# Altium schematic sheet DDR4.SchDoc
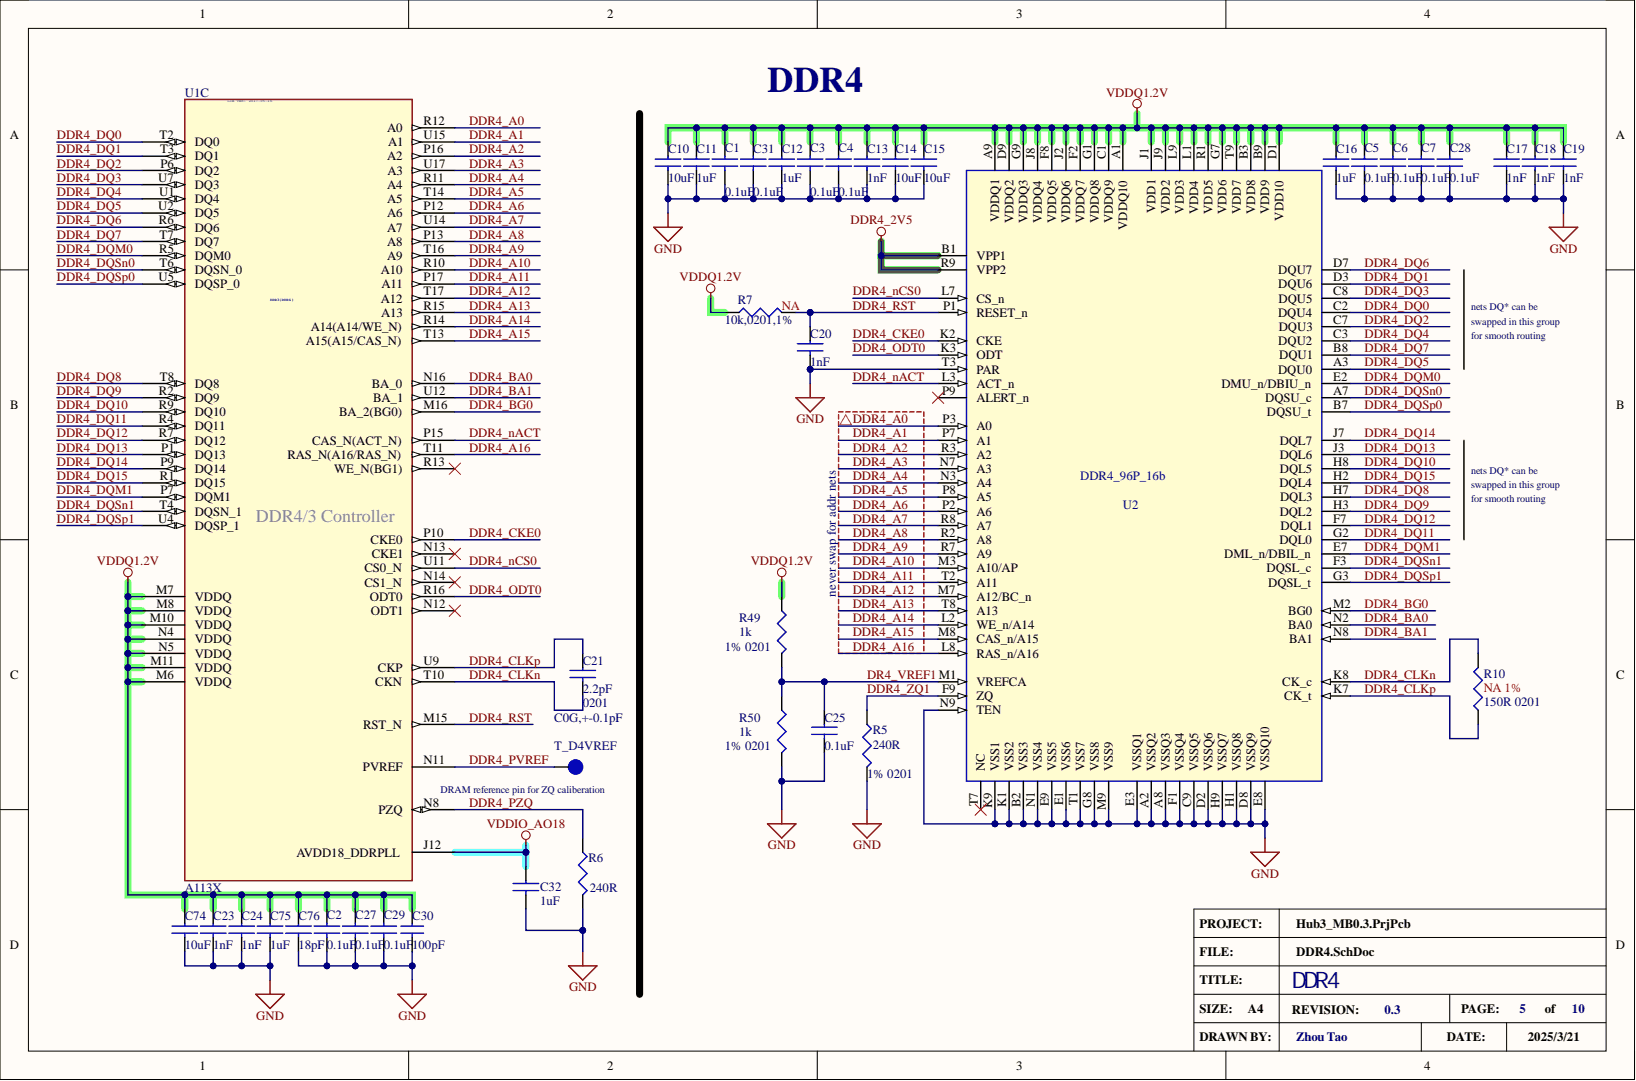
[diagram p0: DDR4.SchDoc]

## Parts
C1  0.1uF  [C0201]
C2  0.1uF  [C0201]
C3  0.1uF  [C0201]
C4  0.1uF  [C0201]
C5  0.1uF  [C0201]
C6  0.1uF  [C0201]
C7  0.1uF  [C0201]
C10  10uF  [C0603]
C11  1uF  [C0201]
C12  1uF  [C0201]
C13  value 1nF  [C0201]  (hidden comment: Cap_1nF_0201_50V)
C14  10uF  [C0603]
C15  10uF  [C0603]
C16  1uF  [C0201]
C17  value 1nF  [C0201]  (hidden comment: Cap_1nF_0201_50V)
C18  value 1nF  [C0201]  (hidden comment: Cap_1nF_0201_50V)
C19  value 1nF  [C0201]  (hidden comment: Cap_1nF_0201_50V)
C20  1nF  [C0201]
C21  0201  value 2.2pF  [C0201]
C23  value 1nF  [C0201]  (hidden comment: Cap_1nF_0201_50V)
C24  value 1nF  [C0201]  (hidden comment: Cap_1nF_0201_50V)
C25  0.1uF  [C0201]
C27  0.1uF  [C0201]
C28  0.1uF  [C0201]
C29  0.1uF  [C0201]
C30  value 100pF  [C0402]  (hidden comment: Cap_100pF_0402_50V)
C31  0.1uF  [C0201]
C32  1uF  [C0201]
C74  10uF  [C0603]
C75  1uF  [C0201]
C76  18pF  [C0402]
R5  1% 0201  value 240R  [R0201]
R6  240R  [R0201]
R7  NA  Des: 10k,0201,1%  [R0201]  (no BOM)
R10  NA 1%  value 150R 0201  [R0201]  (no BOM)
R49  1% 0201  value 1k  [R0201]
R50  1% 0201  value 1k  [R0201]
T_D4VREF  (no value)  [TP12]  (no BOM)
U1  A113X  [A113X_284]  (part C)
U2  DDR4_96P_16b  [BGA96-0P8MM_DDR4]

## Nets
DDR4_2V5: U2.B1(VPP1) U2.R9(VPP2)
GND: C1.2 C2.2 C3.2 C4.2 C5.2 C6.2 C7.2 C10.2 C11.2 C12.2 C13.2 C14.2 C15.2 C16.2 C17.2 C18.2 C19.2 C20.2 C23.2 C24.2 C25.2 C27.2 C28.2 C29.2 C30.2 C31.2 C32.2 C74.2 C75.2 C76.2 R5.2 R6.2 R50.2 U2.A2(VSSQ2) U2.A8(VSSQ3) U2.B2(VSS3) U2.C9(VSSQ5) U2.D2(VSSQ6) U2.D8(VSSQ9) U2.E1(VSS6) U2.E3(VSSQ1) U2.E8(VSSQ10) U2.E9(VSS5) U2.F1(VSSQ4) U2.G8(VSS8) U2.H1(VSSQ8) U2.H9(VSSQ7) U2.K1(VSS2) U2.K9(VSS1) U2.M9(VSS9) U2.N1(VSS4) U2.N9(TEN) U2.T1(VSS7) U2.T3(PAR)
VDDIO_AO18: C32.1 U1.J12(AVDD18_DDRPLL)
VDDQ1.2V: C1.1 C2.1 C3.1 C4.1 C5.1 C6.1 C7.1 C10.1 C11.1 C12.1 C13.1 C14.1 C15.1 C16.1 C17.1 C18.1 C19.1 C23.1 C24.1 C27.1 C28.1 C29.1 C30.1 C31.1 C74.1 C75.1 C76.1 R7.1 R49.1 U1.M6(VDDQ) U1.M7(VDDQ) U1.M8(VDDQ) U1.M10(VDDQ) U1.M11(VDDQ) U1.N4(VDDQ) U1.N5(VDDQ) U2.A1(VDDQ10) U2.A9(VDDQ1) U2.B3(VDD8) U2.B9(VDD9) U2.C1(VDDQ9) U2.D1(VDD10) U2.D9(VDDQ2) U2.F2(VDDQ7) U2.F8(VDDQ5) U2.G1(VDDQ8) U2.G7(VDD6) U2.G9(VDDQ3) U2.J1(VDD1) U2.J2(VDDQ6) U2.J8(VDDQ4) U2.J9(VDD2) U2.L1(VDD4) U2.L9(VDD3) U2.R1(VDD5) U2.T9(VDD7)
DDR4_A0: U1.R12(A0) U2.P3(A0)
DDR4_A1: U1.U15(A1) U2.P7(A1)
DDR4_A2: U1.P16(A2) U2.R3(A2)
DDR4_A3: U1.U17(A3) U2.N7(A3)
DDR4_A4: U1.R11(A4) U2.N3(A4)
DDR4_A5: U1.T14(A5) U2.P8(A5)
DDR4_A6: U1.P12(A6) U2.P2(A6)
DDR4_A7: U1.U14(A7) U2.R8(A7)
DDR4_A8: U1.P13(A8) U2.R2(A8)
DDR4_A9: U1.T16(A9) U2.R7(A9)
DDR4_A10: U1.R10(A10) U2.M3(A10/AP)
DDR4_A11: U1.P17(A11) U2.T2(A11)
DDR4_A12: U1.T17(A12) U2.M7(A12/BC_n)
DDR4_A13: U1.R15(A13) U2.T8(A13)
DDR4_A14: U1.R14(A14(A14/WE_N)) U2.L2(WE_n/A14)
DDR4_A15: U1.T13(A15(A15/CAS_N)) U2.M8(CAS_n/A15)
DDR4_A16: U1.T11(RAS_N(A16/RAS_N)) U2.L8(RAS_n/A16)
DDR4_BA0: U1.N16(BA_0) U2.N2(BA0)
DDR4_BA1: U1.U12(BA_1) U2.N8(BA1)
DDR4_BG0: U1.M16(BA_2(BG0)) U2.M2(BG0)
DDR4_CKE0: U1.P10(CKE0) U2.K2(CKE)
DDR4_CLKn: C21.2 R10.1 U1.T10(CKN) U2.K8(CK_c)
DDR4_CLKp: C21.1 R10.2 U1.U9(CKP) U2.K7(CK_t)
DDR4_DQ0: U1.T2(DQ0) U2.C2(DQU4)
DDR4_DQ1: U1.T3(DQ1) U2.D3(DQU6)
DDR4_DQ2: U1.P6(DQ2) U2.C7(DQU3)
DDR4_DQ3: U1.U7(DQ3) U2.C8(DQU5)
DDR4_DQ4: U1.U1(DQ4) U2.C3(DQU2)
DDR4_DQ5: U1.U2(DQ5) U2.A3(DQU0)
DDR4_DQ6: U1.R6(DQ6) U2.D7(DQU7)
DDR4_DQ7: U1.T7(DQ7) U2.B8(DQU1)
DDR4_DQ8: U1.T8(DQ8) U2.H7(DQL3)
DDR4_DQ9: U1.R2(DQ9) U2.H3(DQL2)
DDR4_DQ10: U1.R9(DQ10) U2.H8(DQL5)
DDR4_DQ11: U1.R4(DQ11) U2.G2(DQL0)
DDR4_DQ12: U1.R7(DQ12) U2.F7(DQL1)
DDR4_DQ13: U1.P1(DQ13) U2.J3(DQL6)
DDR4_DQ14: U1.P9(DQ14) U2.J7(DQL7)
DDR4_DQ15: U1.R1(DQ15) U2.H2(DQL4)
DDR4_DQM0: U1.R5(DQM0) U2.E2(DMU_n/DBIU_n)
DDR4_DQM1: U1.P7(DQM1) U2.E7(DML_n/DBIL_n)
DDR4_DQSn0: U1.T6(DQSN_0) U2.A7(DQSU_c)
DDR4_DQSn1: U1.T4(DQSN_1) U2.F3(DQSL_c)
DDR4_DQSp0: U1.U5(DQSP_0) U2.B7(DQSU_t)
DDR4_DQSp1: U1.U4(DQSP_1) U2.G3(DQSL_t)
DDR4_nACT: U1.P15(CAS_N(ACT_N)) U2.L3(ACT_n)
DDR4_nCS0: U1.U11(CS0_N) U2.L7(CS_n)
DDR4_ODT0: U1.R16(ODT0) U2.K3(ODT)
DDR4_PVREF: T_D4VREF.1 U1.N11(PVREF)
DDR4_PZQ: R6.1 U1.N8(PZQ)
DDR4_RST: C20.1 R7.2 U1.M15(RST_N) U2.P1(RESET_n)
DDR4_ZQ1: R5.1 U2.F9(ZQ)
DR4_VREF1: C25.1 R49.2 R50.1 U2.M1(VREFCA)

Not connected: U1 N12(ODT1) N13(CKE1) N14(CS1_N) R13(WE_N(BG1)); U2 P9(ALERT_n) T7(NC)

## Library data of the parts
C1-C7 C25 C27-C29 C31 = Cap_0.1uF_0201_16V: Manufacturer: EYANG; Part Number: C0201X5R104K160NTA; Des: 0.1uF,0201,16V,X5R,10%; Component Kind: Standard; value: 0.1uF; Library Name: Lib_sch_Hubv3_2332.SchLib
C10 C14 C15 C74 = Cap_10uF_0603_10%_10V: Manufacturer: Samsung; Part Number: CL10A106KP8NNNC; Des: 10uF,0603,X5R, 10%, 10V; Component Kind: Standard; value: 10uF; Library Name: Lib_sch_Hubv3_2332.SchLib
C11 C12 C16 C32 C75 = Cap_1uF_0201_6.3V: Manufacturer: Walsin Tech; Part Number: 0201X105K6R3CT; Des: 1uF,0201,6.3V,10%,X5R; Component Kind: Standard; value: 1uF; Library Name: Lib_sch_Hubv3_2332.SchLib
C13 C17-C20 C23 C24 = Cap_1nF_0201_50V: Manufacturer: EYANG; Part Number: C0201X7R102K500NTA; Des: 1nF,0201,50V,X7R,10%; Component Kind: Standard; Library Name: Lib_sch_Hubv3_2332.SchLib
  C20: value: 1nF
C21 = Cap_2.2pF_0201_25V: Manufacturer: Murata; Part Number: GJM0335C1E2R2BB01D; Des: 2.2pF,0201,25V,+-0.1p,C0G/NP0; Component Kind: Standard; Library Name: Lib_sch_sisv3_2332.SchLib
C30 = Cap_100pF_0402_50V: Manufacturer: Yageo; Part Number: CC0402JRNPO9BN101; Des: 100pF,0402,50V,5%,C0G; Component Kind: Standard; Library Name: Lib_sch_Hubv3_2332.SchLib
C76 = Cap_18pF_0402_5%_16V: Manufacturer: KEMET; Part Number: C0402C180J4GAC7867; Des: 18pF,0402,16V,5%,NP0,low ESL; Component Kind: Standard; value: 18pF; Library Name: Lib_sch_Hubv3_2332.SchLib
R5 R6 = RES_240R_0201_1%: Manufacturer: Yageo; part number: RC0201FR-07240RL; Des: 240R,0201,1%,1/20w; Component Kind: Standard; Library Name: Lib_sch_spk_V0_180901.SchLib
  R6: value: 240R
R7 = RES_10k_0201_1%: Manufacturer: Yageo; part number: RC0201FR-0710KL; value: 10k; Library Name: Lib_sch_sisv3_2332.SchLib
R10 = RES_150R_0201_1%: Manufacturer: Yageo; part number: RC0201FR-07150RL; Des: 150R,0201,1%; Library Name: Lib_sch_spk_V0_180901.SchLib
R49 R50 = RES_1k_0201_1%: Manufacturer: Yageo; part number: RC0201FR-071KL; Des: 1k,0201,1%,1/20w; Component Kind: Standard; Library Name: Lib_sch_spk_V0_180901.SchLib
T_D4VREF = T_POINT_R_1: Des: circle test pad (Dia=0.3mm ); Library Name: Lib_sch_spk_V0_181010.SchLib
U1 = A113X_20170516_V17: Manufacturer: AmLogic; Des: A113X, BGA,V17; Component Kind: Standard; Part Number: A113X; value: A113X; Library Name: Lib_sch_Hubv3_2332.SchLib
U2 = DDR4_96P_16b: Manufacturer: Samsung; Part Number: K4AAG165WA-BCTD; Des: DDR4, 16Gb, X16, 1.2V, 2666; value: 2GB; Component Kind: Standard; Library Name: Lib_sch_sisv3_2332.SchLib
